# Altium schematic sheet Модуль_p1.SchDoc(A1)
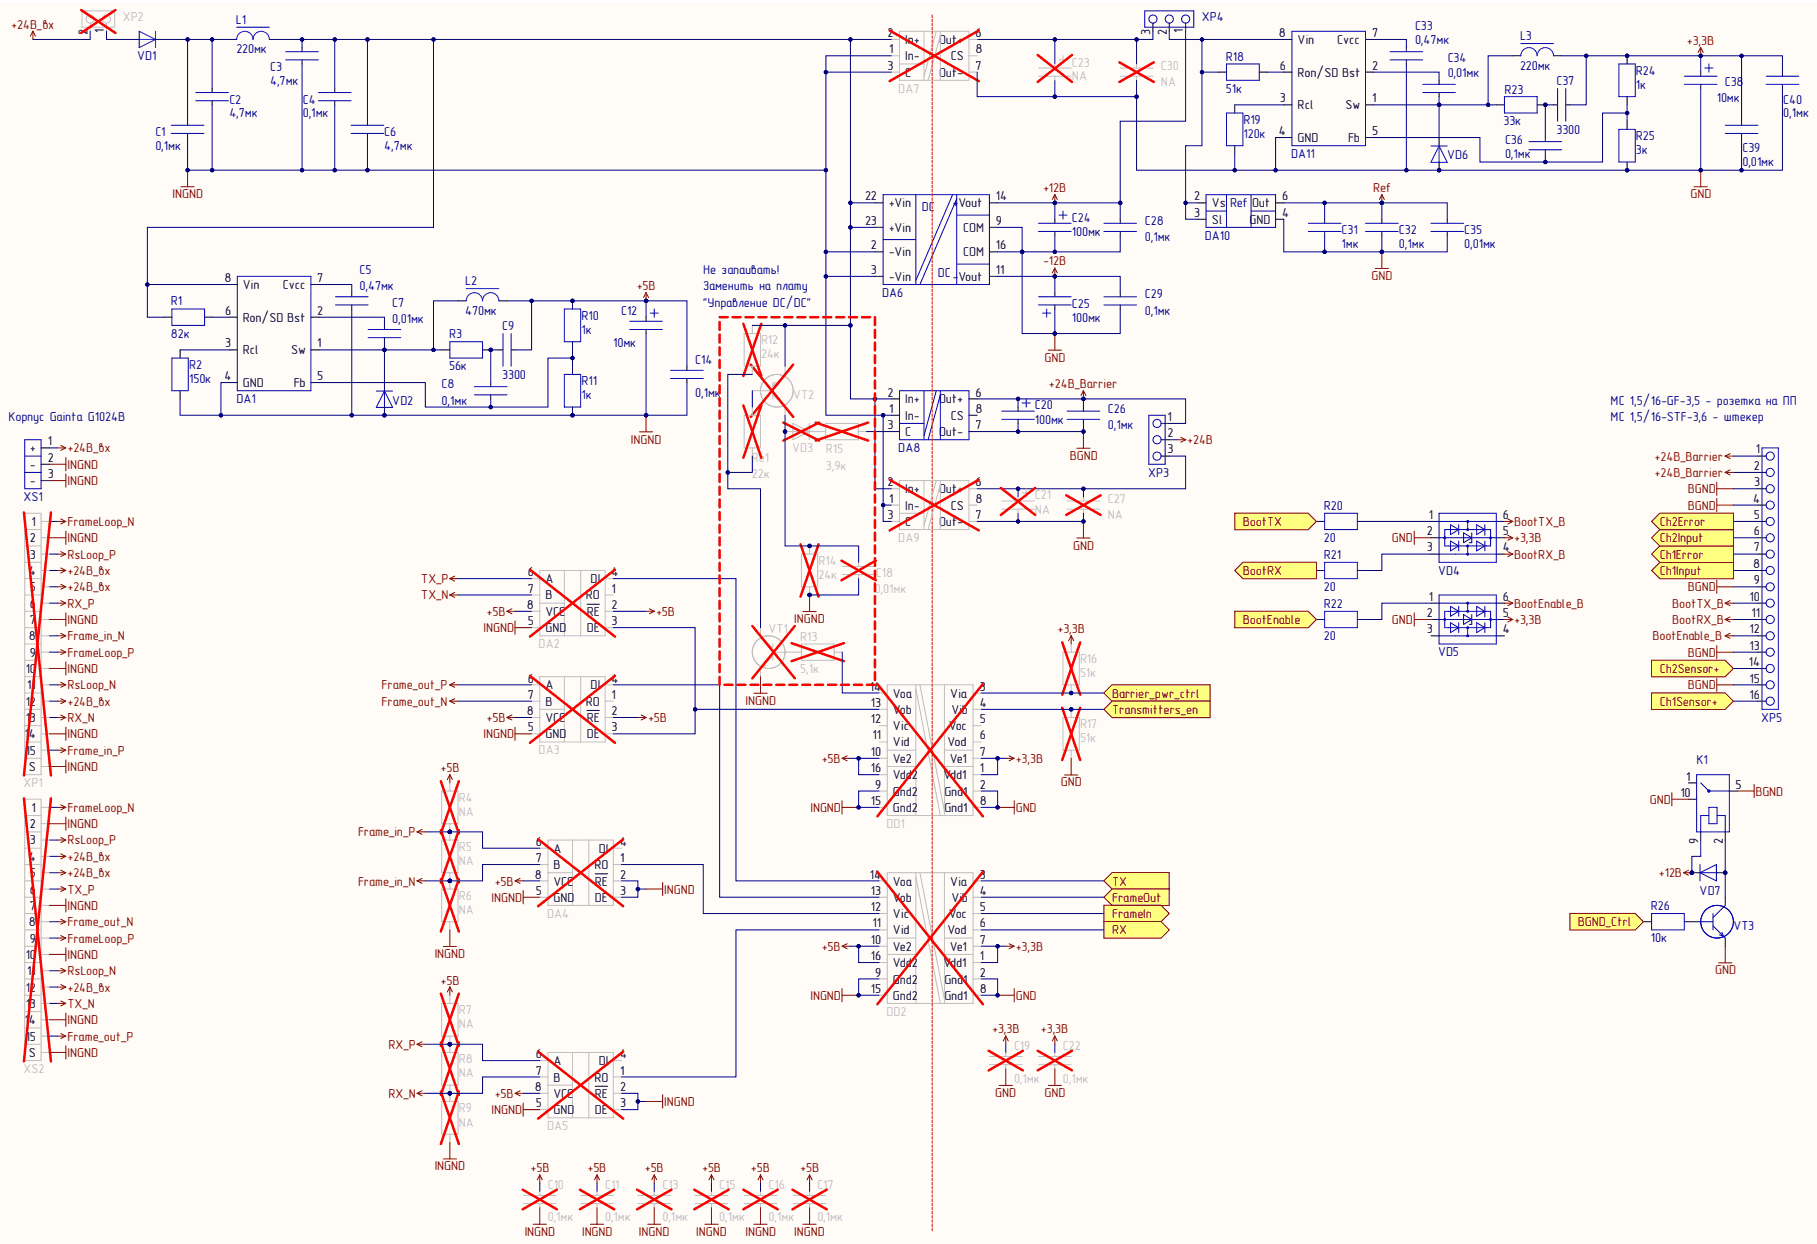
[diagram p0: Модуль_p1.SchDoc]

## Parts
C1  value 0,1мк  [C_0805]  (hidden comment: C_0805)
C2  value 4,7мк  [C_1210]  (hidden comment: C_1210)
C3  value 4,7мк  [C_1210]  (hidden comment: C_1210)
C4  value 0,1мк  [C_0805]  (hidden comment: C_0805)
C5  value 0,47мк  [C_0805]  (hidden comment: C_0805)
C6  value 4,7мк  [C_1210]  (hidden comment: C_1210)
C7  value 0,01мк  [C_0805]  (hidden comment: C_0805)
C8  value 0,1мк  [C_0805]  (hidden comment: C_0805)
C9  value 3300  [C_0805]  (hidden comment: C_0805)
C10  value 0,1мк  [C_0805]  (hidden comment: C_0805)
C11  value 0,1мк  [C_0805]  (hidden comment: C_0805)
C12  value 10мк  [C_TAN_B]  (hidden comment: C_TAN_B)
C13  value 0,1мк  [C_0805]  (hidden comment: C_0805)
C14  value 0,1мк  [C_0805]  (hidden comment: C_0805)
C15  value 0,1мк  [C_0805]  (hidden comment: C_0805)
C16  value 0,1мк  [C_0805]  (hidden comment: C_0805)
C17  value 0,1мк  [C_0805]  (hidden comment: C_0805)
C18  value 0,01мк  [C_0805]  (hidden comment: C_0805)
C19  value 0,1мк  [C_0805]  (hidden comment: C_0805)
C20  value 100мк  [C_ELV_E]  (hidden comment: C_ELV_E)
C21  value NA  [C_ELV_E]  (hidden comment: C_ELV_E)
C22  value 0,1мк  [C_0805]  (hidden comment: C_0805)
C23  value NA  [C_ELV_E]  (hidden comment: C_ELV_E)
C24  value 100мк  [C_ELV_E]  (hidden comment: C_ELV_E)
C25  value 100мк  [C_ELV_E]  (hidden comment: C_ELV_E)
C26  value 0,1мк  [C_0805]  (hidden comment: C_0805)
C27  value NA  [C_0805]  (hidden comment: C_0805)
C28  value 0,1мк  [C_0805]  (hidden comment: C_0805)
C29  value 0,1мк  [C_0805]  (hidden comment: C_0805)
C30  value NA  [C_0805]  (hidden comment: C_0805)
C31  value 1мк  [C_0805]  (hidden comment: C_0805)
C32  value 0,1мк  [C_0805]  (hidden comment: C_0805)
C33  value 0,47мк  [C_0805]  (hidden comment: C_0805)
C34  value 0,01мк  [C_0805]  (hidden comment: C_0805)
C35  value 0,01мк  [C_0805]  (hidden comment: C_0805)
C36  value 0,1мк  [C_0805]  (hidden comment: C_0805)
C37  value 3300  [C_0805]  (hidden comment: C_0805)
C38  value 10мк  [C_TAN_B]  (hidden comment: C_TAN_B)
C39  value 0,01мк  [C_0805]  (hidden comment: C_0805)
C40  value 0,1мк  [C_0805]  (hidden comment: C_0805)
DA1  LM5009  [MSOP8]  (value not drawn)
DA2  ADM1485JR  [SO8]  (value not drawn)
DA3  ADM1485JR  [SO8]  (value not drawn)
DA4  ADM1485JR  [SO8]  (value not drawn)
DA5  ADM1485JR  [SO8]  (value not drawn)
DA6  AM3T-2412D  [DIP24]  (value not drawn)
DA7  WRB2412S-3WR2  [WRB24xxS-3W]  (value not drawn)
DA8  WRB2424S-3WR2  [WRB24xxS-3W]  (value not drawn)
DA9  WRB2424S-3WR2  [WRB24xxS-3W]  (value not drawn)
DA10  REF193xS  [SO8]  (value not drawn)
DA11  LM5009  [MSOP8]  (value not drawn)
DD1  ADUM1402CRW  [SOIC-16(W)]  (value not drawn)
DD2  ADUM1402CRW  [SOIC-16(W)]  (value not drawn)
K1  G5V-1  [G5V-1]  (value not drawn)
L1  value 220мк  [SDR0604]  (hidden comment: L_0604)
L2  value 470мк  [SDR0604]  (hidden comment: L_0604)
L3  value 220мк  [SDR0604]  (hidden comment: L_0604)
R1  value 82к  [R_0805]  (hidden comment: R_0805)
R2  value 150к  [R_0805]  (hidden comment: R_0805)
R3  value 56к  [R_0805]  (hidden comment: R_0805)
R4  value NA  [R_0805]  (hidden comment: R_0805)
R5  value NA  [R_0805]  (hidden comment: R_0805)
R6  value NA  [R_0805]  (hidden comment: R_0805)
R7  value NA  [R_0805]  (hidden comment: R_0805)
R8  value NA  [R_0805]  (hidden comment: R_0805)
R9  value NA  [R_0805]  (hidden comment: R_0805)
R10  value 1к  [R_0805]  (hidden comment: R_0805)
R11  value 1к  [R_0805]  (hidden comment: R_0805)
R12  value 24к  [R_0805]  (hidden comment: R_0805)
R13  value 5,1к  [R_0805]  (hidden comment: R_0805)
R14  value 24к  [R_0805]  (hidden comment: R_0805)
R15  value 3,9к  [R_0805]  (hidden comment: R_0805)
R16  value 51к  [R_0805]  (hidden comment: R_0805)
R17  value 51к  [R_0805]  (hidden comment: R_0805)
R18  value 51к  [R_0805]  (hidden comment: R_0805)
R19  value 120к  [R_0805]  (hidden comment: R_0805)
R20  value 20  [R_0805]  (hidden comment: R_0805)
R21  value 20  [R_0805]  (hidden comment: R_0805)
R22  value 20  [R_0805]  (hidden comment: R_0805)
R23  value 33к  [R_0805]  (hidden comment: R_0805)
R24  value 1к  [R_0805]  (hidden comment: R_0805)
R25  value 3к  [R_0805]  (hidden comment: R_0805)
R26  value 10к  [R_0805]  (hidden comment: R_0805)
Re1  value 22к  [R_0805]  (hidden comment: R_0805)
VD1  SM4007  [SM400]  (value not drawn)
VD2  PMEG6010CEH  [SOD123F]  (value not drawn)
VD3  PMEG6010CEH  [SOD123F]  (value not drawn)
VD4  USBLC6  [SOT23-6]  (value not drawn)
VD5  USBLC6  [SOT23-6]  (value not drawn)
VD6  PMEG6010CEH  [SOD123F]  (value not drawn)
VD7  LL4148  [SOD80_SHORT]  (value not drawn)
VT1  BC847  [SOT23]  (value not drawn)
VT2  BC857  [SOT23]  (value not drawn)
VT3  BC847  [SOT23]  (value not drawn)
XP1  DRB-15M  [DRB-15M]  (value not drawn)
XP2  PLS-2  [PLS-2]  (value not drawn)
XP3  PLS-3  [PLS-3]  (value not drawn)
XP4  PLS-3  [PLS-3]  (value not drawn)
XP5  MC 1,5/16-GF-3,5  [MC 1,5/16-GF-3,5]
XS1  16PJ031  [16PJ031]  (value not drawn)
XS2  DRB-15F  [DRB-15F]  (value not drawn)

## Ports
Barrier_pwr_ctrl, BGND_Ctrl, BootEnable, BootRX, BootTX, Ch1Error, Ch1Input, Ch1Sensor+, Ch2Error, Ch2Input, Ch2Sensor+, FrameIn, FrameOut, RX, Transmitters_en, TX

## Nets
+3,3В: C19.2 C22.2 C37.1 C38.2 C39.2 C40.2 DD1.1(Vdd1) DD1.7(Ve1) DD2.1(Vdd1) DD2.7(Ve1) L3.2 R16.1 R24.1 VD4.5 VD5.5
+5В: C9.1 C10.2 C11.2 C12.2 C13.2 C14.2 C15.2 C16.2 C17.2 DA2.2(RE) DA2.8(VCC) DA3.2(RE) DA3.8(VCC) DA4.8(VCC) DA5.8(VCC) DD1.10(Ve2) DD1.16(Vdd2) DD2.10(Ve2) DD2.16(Vdd2) L2.2 R4.1 R7.1 R10.1
+12В: C24.2 C28.2 DA6.14(+Vout) K1.9 VD7.1 XP4.1
+24В: XP3.2
+24В_Barrier: C20.2 C26.2 DA8.6(Out+) XP3.1 XP5.1 XP5.2
+24В_вх: XP1.4 XP1.5 XP1.12 XP2.2 XS1.1 XS2.4 XS2.5 XS2.12
-12В: C25.1 C29.2 DA6.11(DC_-Vout)
BGND: C20.1 C26.1 DA8.7(Out-) K1.5 XP5.3 XP5.4 XP5.9 XP5.13 XP5.15
BootEnable_B: VD5.6 XP5.12
BootRX_B: VD4.4 XP5.11
BootTX_B: VD4.6 XP5.10
Frame_in_N: DA4.7(B) R5.2 R6.1 XP1.8
Frame_in_P: DA4.6(A) R4.2 R5.1 XP1.15
Frame_out_N: DA3.7(B) XS2.8
Frame_out_P: DA3.6(A) XS2.15
FrameLoop_N: XP1.1 XS2.1
FrameLoop_P: XP1.9 XS2.9
GND: C19.1 C21.1 C22.1 C23.1 C24.1 C25.2 C27.1 C28.1 C29.1 C30.1 C31.1 C32.1 C33.1 C35.1 C38.1 C39.1 C40.1 DA6.9(COM) DA6.16(COM) DA7.7(Out-) DA9.7(Out-) DA10.4(GND) DA11.4(GND) DD1.2(Gnd1) DD1.8(Gnd1) DD2.2(Gnd1) DD2.8(Gnd1) K1.10 R17.2 R19.2 R25.2 VD4.2 VD5.2 VD6.2 VT3.2
INGND: C1.1 C2.1 C3.1 C4.1 C5.1 C6.1 C10.1 C11.1 C12.1 C13.1 C14.1 C15.1 C16.1 C17.1 C18.1 DA1.4(GND) DA2.5(GND) DA3.5(GND) DA4.2(RE) DA4.3(DE) DA4.5(GND) DA5.2(RE) DA5.3(DE) DA5.5(GND) DA6.2(-Vin) DA6.3(-Vin) DA7.1(In-) DA7.3(C) DA8.1(In-) DA9.1(In-) DA9.3(C) DD1.9(Gnd2) DD1.15(Gnd2) DD2.9(Gnd2) DD2.15(Gnd2) R2.1 R6.2 R9.2 R11.2 R14.2 VD2.2 VT1.2 XP1.2 XP1.7 XP1.10 XP1.14 XP1.16(S) XS1.2 XS1.3 XS2.2 XS2.7 XS2.10 XS2.14 XS2.16(S)
Ref: C31.2 C32.2 C35.2 DA10.6
RsLoop_N: XP1.11 XS2.11
RsLoop_P: XP1.3 XS2.3
RX_N: DA5.7(B) R8.2 R9.1 XP1.13
RX_P: DA5.6(A) R7.2 R8.1 XP1.6
TX_N: DA2.7(B) XS2.13
TX_P: DA2.6(A) XS2.6
Barrier_pwr_ctrl: DD1.3(Via) R16.2 ; port Barrier_pwr_ctrl
BGND_Ctrl: R26.2 ; port BGND_Ctrl
BootEnable: R22.1 ; port BootEnable
BootRX: R21.1 ; port BootRX
BootTX: R20.1 ; port BootTX
Ch1Error: XP5.7 ; port Ch1Error
Ch1Input: XP5.8 ; port Ch1Input
Ch1Sensor+: XP5.16 ; port Ch1Sensor+
Ch2Error: XP5.5 ; port Ch2Error
Ch2Input: XP5.6 ; port Ch2Input
Ch2Sensor+: XP5.14 ; port Ch2Sensor+
FrameIn: DD2.5(Voc) ; port FrameIn
FrameOut: DD2.4(Vib) ; port FrameOut
RX: DD2.6(Vod) ; port RX
Transmitters_en: DD1.4(Vib) R17.1 ; port Transmitters_en
TX: DD2.3(Via) ; port TX
NetC1_2: C1.2 C2.2 L1.1 VD1.1
NetC3_2: C3.2 C4.2 C6.2 DA1.8(Vin) DA6.22(+Vin) DA6.23(+Vin) DA7.2(In+) DA8.2(In+) DA9.2(In+) L1.2 R1.1 R12.1 VT2.2
NetC5_2: C5.2 DA1.7(Cvcc)
NetC7_1: C7.1 DA1.1(Sw) L2.1 R3.2 VD2.1
NetC7_2: C7.2 DA1.2(Bst)
NetC8_1: C8.1 DA1.5(Fb) R10.2 R11.1
NetC8_2: C8.2 C9.2 R3.1
NetC18_2: C18.2 R14.1 VD3.2 VT2.3
NetC21_2: C21.2 C27.2 DA9.6(Out+) XP3.3
NetC23_2: C23.2 C30.2 DA7.6(Out+) XP4.3
NetC33_2: C33.2 DA11.7(Cvcc)
NetC34_1: C34.1 DA11.1(Sw) L3.1 R23.2 VD6.1
NetC34_2: C34.2 DA11.2(Bst)
NetC36_1: C36.1 DA11.5(Fb) R24.2 R25.1
NetC36_2: C36.2 C37.2 R23.1
NetDA1_3: DA1.3(Rcl) R2.2
NetDA1_6: DA1.6(Ron/SD) R1.2
NetDA2_1: DA2.1(RO)
NetDA2_3: DA2.3(DE) DA3.3(DE) DD1.13(Vob)
NetDA2_4: DA2.4(DI) DD2.14(Voa)
NetDA3_1: DA3.1(RO)
NetDA3_4: DA3.4(DI) DD2.13(Vob)
NetDA4_1: DA4.1(RO) DD2.12(Vic)
NetDA4_4: DA4.4(DI)
NetDA5_1: DA5.1(RO) DD2.11(Vid)
NetDA5_4: DA5.4(DI)
NetDA7_8: DA7.8(CS)
NetDA8_3: DA8.3(C) R15.1
NetDA8_8: DA8.8(CS)
NetDA9_8: DA9.8(CS)
NetDA10_2: DA10.2(Vs_Ref) DA10.3(Sl) DA11.8(Vin) R18.2 XP4.2
NetDA11_3: DA11.3(Rcl) R19.1
NetDA11_6: DA11.6(Ron/SD) R18.1
NetDD1_5: DD1.5(Voc)
NetDD1_6: DD1.6(Vod)
NetDD1_11: DD1.11(Vid)
NetDD1_12: DD1.12(Vic)
NetDD1_14: DD1.14(Voa) R13.2
NetK1_1: K1.1
NetK1_2: K1.2 VD7.2 VT3.3
NetR12_2: R12.2 Re1.2 VT1.3
NetR13_1: R13.1 VT1.1
NetR15_2: R15.2 VD3.1
NetR20_2: R20.2 VD4.1
NetR21_2: R21.2 VD4.3
NetR22_2: R22.2 VD5.1
NetR26_1: R26.1 VT3.1
NetRe1_1: Re1.1 VT2.1
NetVD1_2: VD1.2 XP2.1
NetVD5_3: VD5.3
NetVD5_4: VD5.4

## Library data of the parts
C1 C4 C26-C37 C39 C40 = C_0805: Конденсатор чип 0805; Наименование: Конденсатор 0805 (X7R,Y5V,NPO); Component Kind: Standard; Library Name: All_design_lib.IntLib
C2 C3 C6 = C_1210: Конденсатор чип 1210; Наименование: Конденсатор 1210 (X7R,Y5V,NPO); Component Kind: Standard; Library Name: All_design_lib.IntLib
C5 C7-C11 C13-C17 C19 C22 = C_0805: Конденсатор чип 0805; Наименование: Конденсатор 0805; Напряжение: 50 В; Тип диэлектрика: X7R; Component Kind: Standard; Library Name: All_design_lib.IntLib
C12 = C_TAN_B: Конденсатор танталовый тип В; Напряжение: 16 В; Component Kind: Standard; Library Name: All_design_lib.IntLib; Наименование: Конденсатор танталовый тип В
C18 = C_0805: Конденсатор чип 0805; Наименование: Конденсатор 0805; Напряжение: 50 В; Тип диэлектрика: (X7R,Y5V,NPO); Component Kind: Standard; Library Name: All_design_lib.IntLib
C20 C21 C23-C25 = C_ELV_E: Конденсатор электролитический тип E; Напряжение: 50 В; Component Kind: Standard; Library Name: All_design_lib.IntLib; Наименование: Конденсатор электролитический тип E
C38 = C_TAN_B: Конденсатор танталовый тип В; Component Kind: Standard; Library Name: All_design_lib.IntLib; Наименование: Конденсатор танталовый тип В
DA1 DA11 = LM5009: Импульсный стабилизатор напряжения; Наименование: Импульсный стабилизатор LM5009MM; Component Kind: Standard
  DA1: Library Name: All_design_lib.SchLib
  DA11: Library Name: All_design_lib.IntLib
DA2-DA5 = ADM1485JR: Операционный усилитель; Наименование: Микросхема ADM1485JR; Component Kind: Standard; Library Name: All_design_lib.IntLib
DA6 = AM3T-2412D: DC/DC преобразователь 24 В -> +-12 В; Наименование: DC/DC преобразователь AM3T-2412D; Component Kind: Standard; Library Name: All_design_lib.IntLib
DA7 = WRB2412S-3WR2: DC/DC преобразователь 24В -> +12В; Наименование: DC/DC преобразователь WRB2412S-3WR2; Component Kind: Standard; Library Name: All_design_lib.SchLib
DA8 DA9 = WRB2424S-3WR2: DC/DC преобразователь 24В -> +24В; Наименование: DC/DC преобразователь WRB2424S-3WR2; Component Kind: Standard; Library Name: All_design_lib.SchLib
DA10 = REF193xS: Источник опорного напряжения; Наименование: ИОН REF193xS; Component Kind: Standard; Library Name: All_design_lib.IntLib
DD1 DD2 = ADUM1402CRW: Цифровая развязка; Наименование: Микросхема ADUM1402CRW; Component Kind: Standard; Library Name: All_design_lib.IntLib
K1 = G5V-1: Реле электромеханическое, Uобмотки 3 В; Наименование: G5V-1, электромеханическое реле; Component Kind: Standard; Library Name: All_design_lib.IntLib
L1-L3 = L_0604: Индуктивность проволочная; Наименование: Индуктивность SDR0604; Component Kind: Standard; Library Name: All_design_lib.IntLib
R1-R11 = R_0805: Резистор чип 0805; Наименование: Резистор 0805 0,125 Вт; Точность: 1%; Component Kind: Standard; Library Name: All_design_lib.IntLib
R12-R26 Re1 = R_0805: Резистор чип 0805; Наименование: Резистор 0805 0,125 Вт; Component Kind: Standard; Library Name: All_design_lib.IntLib
VD1 = SM4007: Диод; Наименование: Диод SM4007; Component Kind: Standard; Library Name: All_design_lib.IntLib
VD2 VD3 VD6 = PMEG6010CEH: Диод быстродействующий 60 В, 1 А; Наименование: Диод PMEG6010CEH; Component Kind: Standard; Library Name: All_design_lib.IntLib
VD4 VD5 = USBLC6: ESD защита для USB; Наименование: Микросхема USBLC6; Component Kind: Standard; Library Name: All_design_lib.IntLib
VD7 = LL4148: Диод; Наименование: Диод LL4148; Component Kind: Standard; Library Name: All_design_lib.IntLib
VT1 VT3 = BC847: Транзитор биполярный NPN, 50 В 100 мА; Наименование: Транзистор BC847; Component Kind: Standard; Library Name: All_design_lib.IntLib
VT2 = BC857: Транзистор биполярный PNP, 50 В 100 мА; Наименование: Транзистор BC857; Component Kind: Standard; Library Name: All_design_lib.IntLib
XP1 = DRB-15M: Разъем D-SUB угловой, 15 контактов; Наименование: Разъем DRB-15M; Component Kind: Standard; Library Name: All_design_lib.IntLib
XP2 = PLS-2: Разъем штыревой однорядный; Наименование: Разъем PLS-40 2 pin; Component Kind: Standard; Library Name: All_design_lib.IntLib
XP3 XP4 = PLS-3: Разъем штыревой однорядный; Наименование: Разъем PLS-40 3 pin; Component Kind: Standard; Library Name: All_design_lib.IntLib
XP5 = MC 1,5/16-GF-3,5: Разъем штыревой угловой на ПП; Наименование: Разъем MC 1,5/16-GF-3,5; Component Kind: Standard; Library Name: All_design_lib.IntLib
XS1 = 16PJ031: Разъем низковольтного питания на плату; Наименование: Разъем 16PJ031; Component Kind: Standard; Library Name: All_design_lib.IntLib
XS2 = DRB-15F: Разъем D-SUB угловой, 15 контактов; Наименование: Разъем DRB-15F; Component Kind: Standard; Library Name: All_design_lib.IntLib
